# Altium schematic sheet ReboostV2__Isense.SchDoc
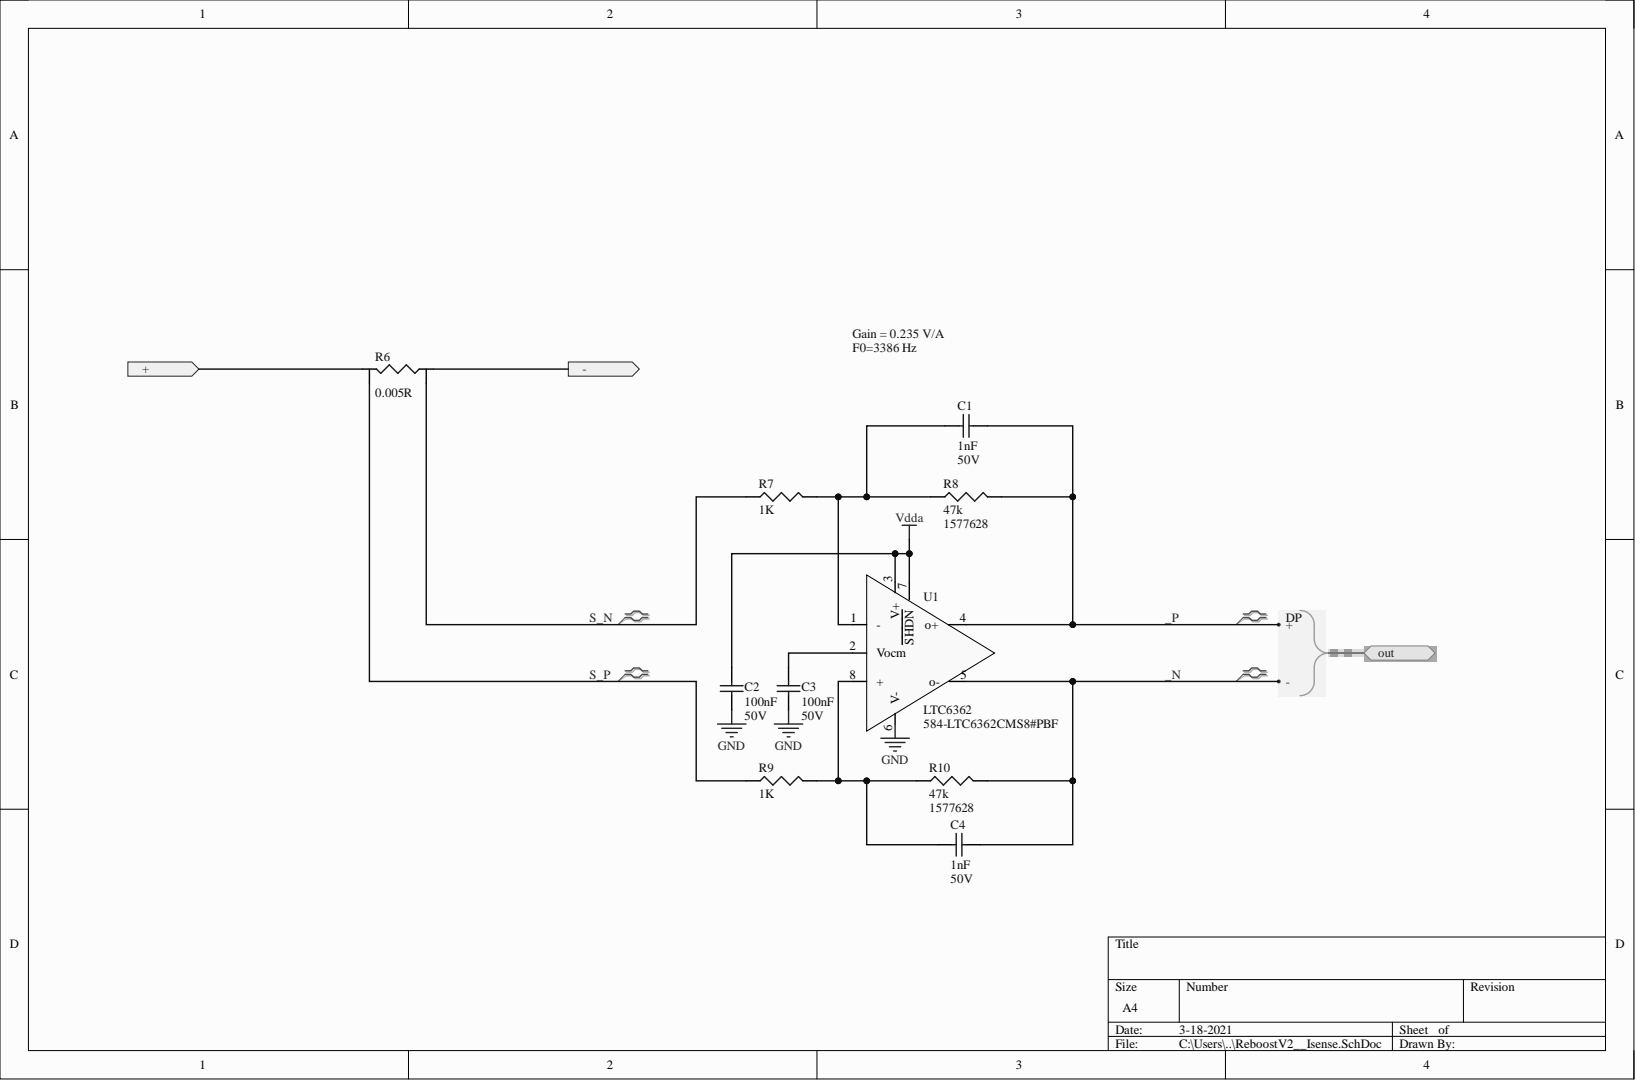
[diagram p0: ReboostV2__Isense.SchDoc]

## Parts
C1  1nF  [C0603]
C2  100nF  Voltage Rating: 50V  [C0603]
C3  100nF  Voltage Rating: 50V  [C0603]
C4  1nF  Voltage Rating: 50V  [C0603]
R6  0.005R  [2512-L-4T]
R7  1K  [0603]
R8  47k  Supplier Part Number 1: 1577628  [0603]
R9  1K  [0603]
R10  47k  [0603]
U1  LTC6362  Supplier Part Number 1: 584-LTC6362CMS8#PBF  [MSOP8]

## Ports
+, -, out, out.+, out.-

## Nets
GND: C2.1 C3.1 U1.6(V-)
Vdda: C2.2 U1.3(V+) U1.7(SHDN)
_N: C4.2 R10.2 U1.5(o-) ; port out
_P: C1.2 R8.2 U1.4(o+) ; port out
S_N: R6.4 R7.1
S_P: R6.3 R9.1
NetC1_1: C1.1 R7.2 R8.1 U1.1(-)
NetC3_2: C3.2 U1.2(Vocm)
NetC4_1: C4.1 R9.2 R10.1 U1.8(+)
NetR6_1: R6.1
NetR6_2: R6.2

## Library data of the parts
C1 C4 = 1nF/0603/CER/50V: Capacitor, 1nF, 50V, X7R; Brand: KEMET; Brand Number: C0603C102K5RACTU; Capacitance Tolerance: ± 10%; Capacitor Case Style: 0603; Capacitance: 1nF; Component Kind: Standard; Dielectric Characteristic: X7R; Library Name: AR_Capacitors_Ceramic.IntLib; Order Code Supplier: 1414608; Price: 0,011; Supplier: Farnell; Temperature Range: -55°C to +125°C
  C1: Voltage Rating: 50V
C2 C3 = 100nF/0603/CER/50V: Capacitor, 100nF, 50V, X7R; Brand: MULTICOMP; Brand Number: MCCA000255; Capacitance Tolerance: ± 10%; Capacitor Case Style: 0603; Capacitance: 100nF; Component Kind: Standard; Dielectric Characteristic: X7R; Library Name: AR_Capacitors_Ceramic.IntLib; Order Code Supplier: 1759122RL; Price: 0,008; Supplier: Farnell; Temperature Range: -55°C to +125°C
R6 = 0.005/2512/2000W/200V: Resistor, 0.005R, 1%, 2W, 50PPM; Brand: OHMITE; Brand Number: FC4L64R005FER; Case Style: 2512; Voltage Rating: 200V; Component Kind: Standard; Library Name: AR_Resistors_SMD.IntLib; Order Code Supplier: 2363991; Power Rating: 2W; Price: 3,07; Resistance: 0.005R; Supplier: Farnell; Temperature Coefficient: ± 50PPM; Temperature Range: -55°C to +170°C
R7 R9 = 1K/0603/0063W/50V: Resistor, 1K, 1%, 0.063W, 50V, 100PPM, 0603; Brand: VISHAY DRALORIC; Brand Number: CRCW06031K00FKEA; Case Style: 0603; Component Kind: Standard; Library Name: AR_Resistors_SMD.IntLib; Order Code Supplier: 2122366; Power Rating: 0.063W; Price: 0,039; Resistance: 1K; Supplier: Farnell; Temperature Coefficient: ± 100PPM; Temperature Range: -55°C to +125°C; Voltage Rating: 50V
R8 R10 = 47K/0603/0063W/50V_1: Resistor, 47K, 0.1%, 0.063W, 50V, 100PPM, 0603; Case Style: 0603; Component Kind: Standard; Library Name: AR_Resistors_SMD.IntLib; Power Rating: 0.063W; Resistance: 47k; Supplier: Farnell; Supplier 1: Farnell; Temperature Coefficient: ± 100PPM; Temperature Range: -55°C to +125°C; Voltage Rating: 50V
  R10: Supplier Part Number 1: 1577628
U1 = LTC6362HMS8: Precision, Low Power Rail-to-Rail Input/Output Differential Op Amp; Component Kind: Standard; Library Name: AR_ICs.IntLib; Supplier 1: Mouser
